# Altium schematic sheet WATH8266.SchDoc
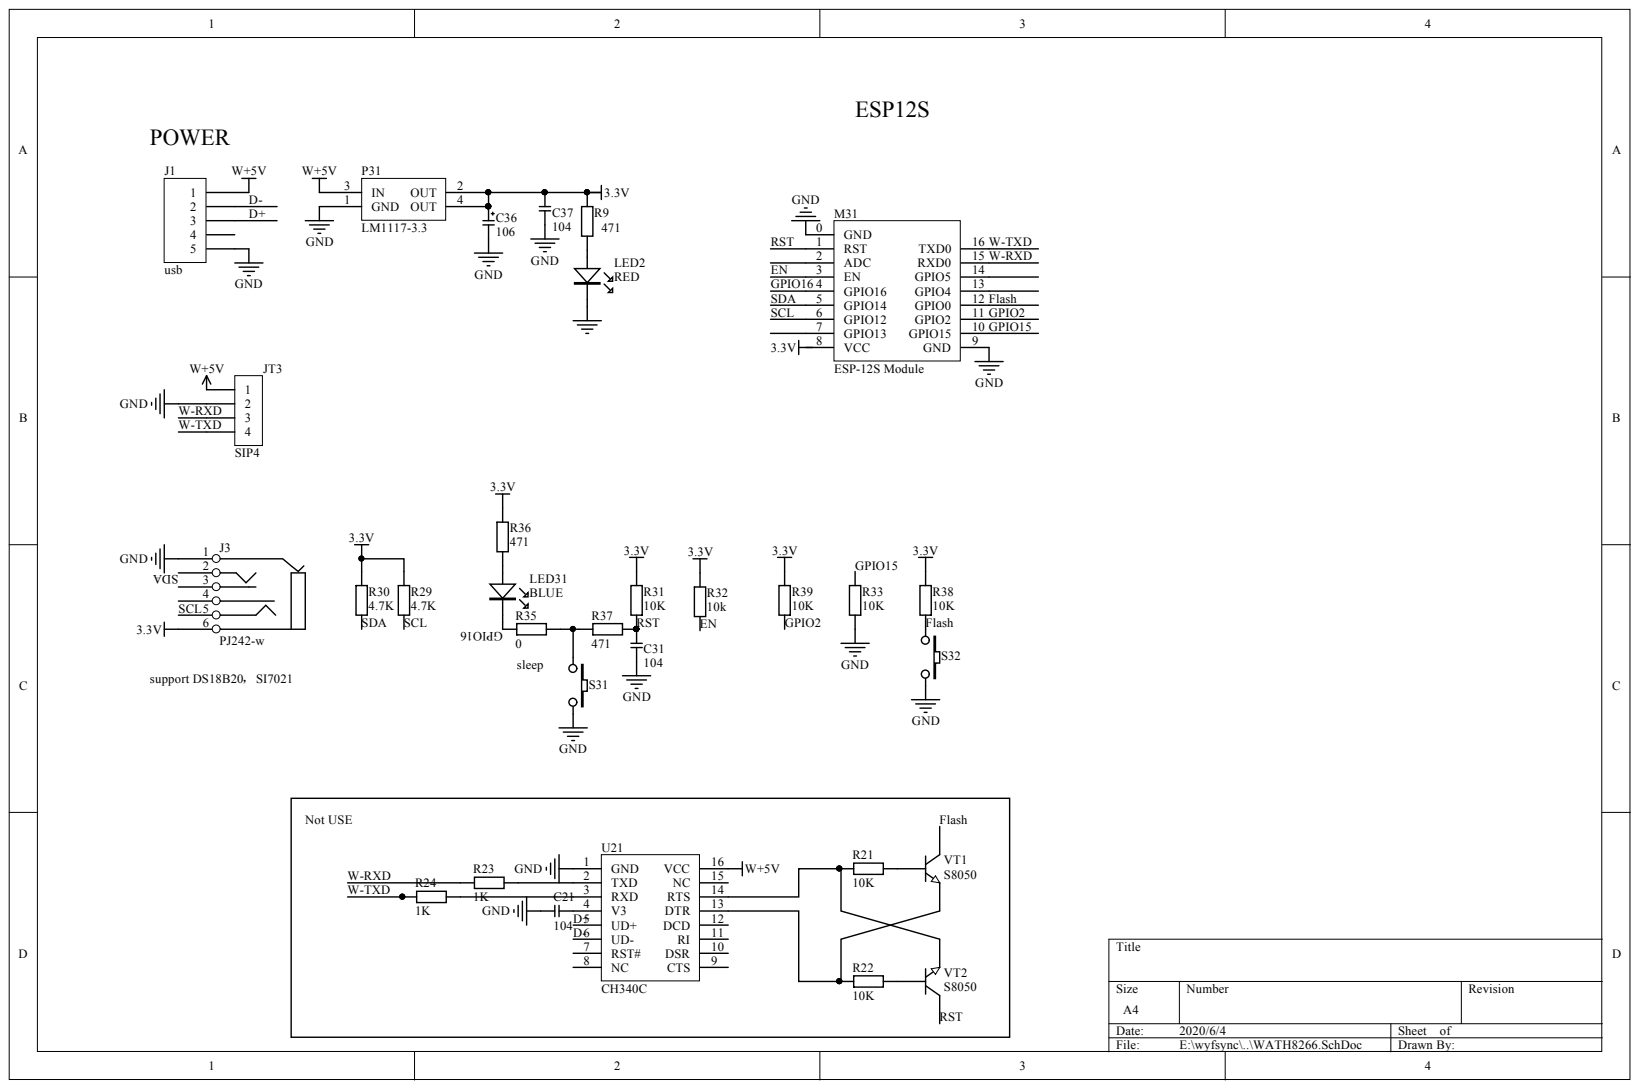
[diagram p0: WATH8266.SchDoc]

## Parts
C21  104  [CAP-SMD-0603]
C31  104  [CAP-SMD-0603]
C36  106  [Cap1206]
C37  104  [CAP-SMD-0603]
J1  usb  [MICROUSB]
J3  PJ242-w  [PJ242-w]
JT3  SIP4  [DB-1X4]
LED2  RED  [1206_LED_R]
LED31  BLUE  [1206_LED_B]
M31  ESP-12S Module  [ESP-12S Module]
P31  LM1117-3.3  [LC-SOT-89(SOT-89-3)]
R9  471  [RES-SMD-0603]
R21  10K  [RES-SMD-0603]
R22  10K  [RES-SMD-0603]
R23  1K  [RES-SMD-0603]
R24  1K  [RES-SMD-0603]
R29  4.7K  [RES-SMD-0603]
R30  4.7K  [RES-SMD-0603]
R31  10K  [RES-SMD-0603]
R32  10k  [RES-SMD-0603]
R33  10K  [RES-SMD-0603]
R35  0  [RES-SMD-0603]
R36  471  [RES-SMD-0603]
R37  471  [RES-SMD-0603]
R38  10K  [RES-SMD-0603]
R39  10K  [RES-SMD-0603]
S31  KEY_RST/USER  [SMT_SW_PTS_820_W]  (value not drawn)
S32  KEY_RST/USER  [SMT_SW_PTS_820_W]  (value not drawn)
U21  CH340C  [SOP-16]
VT1  S8050  [SMT_TRIODE_NPN]
VT2  S8050  [SMT_TRIODE_NPN]

## Nets
3.3V: C36.1 C37.2 J3.6 P31.2(OUT) P31.4(OUT) R9.1 R29.1 R30.1 R31.2 R32.1 R36.1 R38.2 R39.2
GND: C21.1 C31.2 C36.2 C37.1 J1.5 J3.1 JT3.2 LED2.2 M31.0(GND) M31.9(GND) P31.1(GND) R33.1 S31.2 S32.2 U21.1(GND)
W+5V: J1.1 JT3.1 P31.3(IN) U21.16(VCC)
D+: J1.3 U21.5(UD+)
D-: J1.2 U21.6(UD-)
EN: M31.3(EN) R32.2
FLASH: M31.12(GPIO0) R38.1 S32.1 VT1.3
GPIO2: M31.11(GPIO2) R39.1
GPIO15: M31.10(GPIO15)
GPIO16: LED31.2 M31.4(GPIO16) R35.1
RST: C31.1 M31.1(RST) R31.1 R37.2 VT2.3
SCL: J3.5 M31.6(GPIO12) R29.2
SDA: J3.2 M31.5(GPIO14) R30.2
W-RXD: JT3.3 M31.15(RXD0) R23.1
W-TXD: JT3.4 M31.16(TXD0) R24.1
NetC21_2: C21.2 U21.4(V3)
NetLED2_1: LED2.1 R9.2
NetLED31_1: LED31.1 R36.2
NetR21_1: R21.1 U21.14(RTS) VT2.2
NetR21_2: R21.2 VT1.1
NetR22_1: R22.1 U21.13(DTR) VT1.2
NetR22_2: R22.2 VT2.1
NetR23_2: R23.2 U21.2(TXD)
NetR24_2: R24.2 U21.3(RXD)
NetR35_2: R35.2 R37.1 S31.1

Not connected: J1 4; J3 3 4; M31 2(ADC) 7(GPIO13) 8(VCC) 13(GPIO4) 14(GPIO5); R33 2; U21 7(RST#) 8(NC) 9(CTS) 10(DSR) 11(RI) 12(DCD) 15(NC)

## Library data of the parts
C21 C31 C37 = Cap0603: Component Kind: Standard; Description: Cap0603; Library Name: wyf.SchLib
C36 = Cap1206: Component Kind: Standard; Library Name: wyf.SchLib
J1 = Header 5: Header, 5-Pin; Component Kind: Standard; LatestRevisionDate: 17-Jul-2002; LatestRevisionNote: Re-released for DXP Platform.; Library Name: Miscellaneous Connectors.IntLib; Signal Integrity: Connector; Publisher: Altium Limited
LED2 LED31 = LED1206: Component Kind: Standard; Description: LED1206; Library Name: wyf.SchLib
P31 = LM1117: Component Kind: Standard; Library Name: wyf.SchLib
R9 R21-R24 R29-R33 R35-R39 = Res0603: Component Kind: Standard; Description: Res0603; Library Name: wyf.SchLib
S31 S32 = SMT_SW_PTS_820_W: SMT Tactile ‎Switch PTS 820 Series; Component Kind: Standard; Library Name: wyf.SchLib
U21 = CH340C-SOP16: Component Kind: Standard; Description: CH340C; Library Name: wyf.SchLib
VT1 VT2 = SMT_TRIODE_NPN: Surface mount NPN transistor, package SOT-23; Component Kind: Standard; Library Name: wyf.SchLib
J3 = PJ242-w: Component Kind: Standard; Library Name: wyf.SchLib
JT3 = SIP4: Component Kind: Standard; Library Name: wyf.SchLib
M31 = ESP-12S Module: Component Kind: Standard; Description: ESP-12S Module; Library Name: wyf.SchLib
